# Altium schematic sheet Main.SchDoc
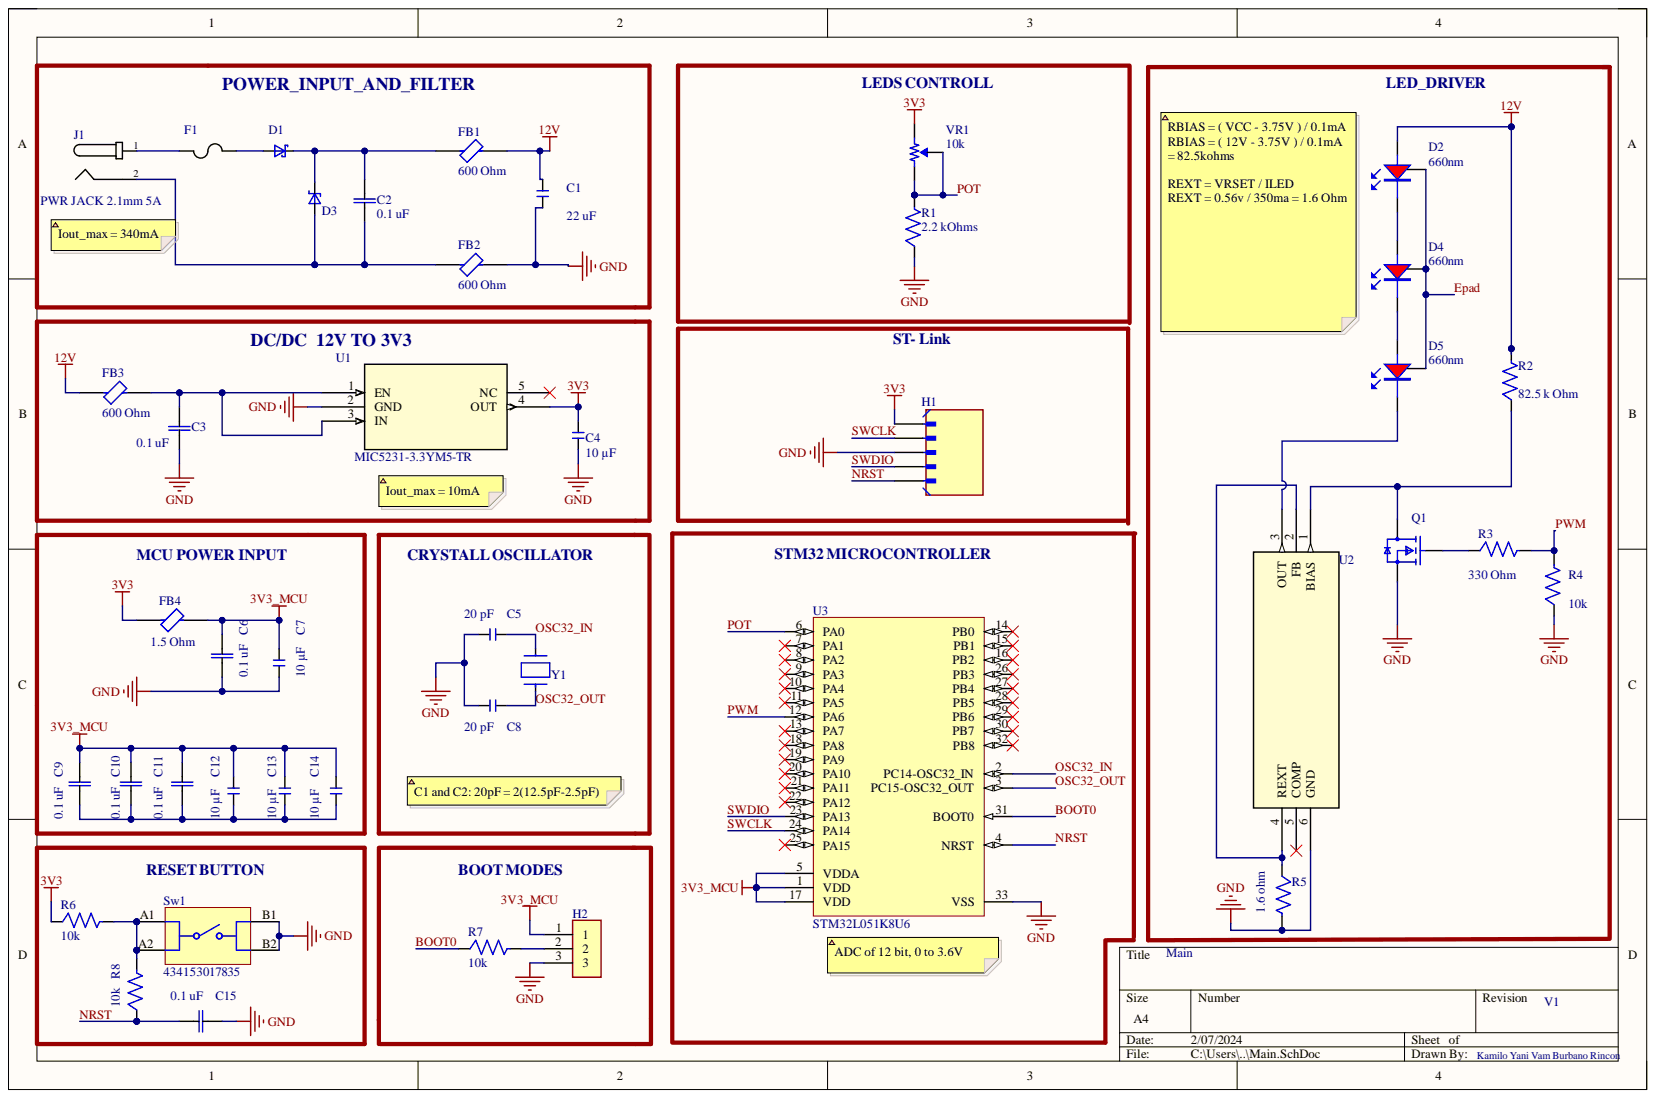
[diagram p0: Main.SchDoc]

## Parts
C1  value 22 uF  [0805]  (hidden comment: 22uF 10%)
C2  value 0.1 uF  [0603]  (hidden comment: 0.1uF  10%)
C3  value 0.1 uF  [0603]  (hidden comment: 0.1uF  10%)
C4  value 10 µF  [0805]  (hidden comment: 10 µF 20%)
C5  value 20 pF  [0603]  (hidden comment: 20pF 5%)
C6  value 0.1 uF  [0603]  (hidden comment: 0.1uF  10%)
C7  value 10 µF  [0805]  (hidden comment: 10 µF 20%)
C8  value 20 pF  [0603]  (hidden comment: 20pF 5%)
C9  value 0.1 uF  [0603]  (hidden comment: 0.1uF  10%)
C10  value 0.1 uF  [0603]  (hidden comment: 0.1uF  10%)
C11  value 0.1 uF  [0603]  (hidden comment: 0.1uF  10%)
C12  value 10 µF  [0805]  (hidden comment: 10 µF 20%)
C13  value 10 µF  [0805]  (hidden comment: 10 µF 20%)
C14  value 10 µF  [0805]  (hidden comment: 10 µF 20%)
C15  value 0.1 uF  [0603]  (hidden comment: 0.1uF  10%)
D1  RSX071VAM30TR  [TUMD2M]  (value not drawn)
D2  660nm  [LED_3535_EP]
D3  ESDA13P70-1U1M  [1610]  (value not drawn)
D4  660nm  [LED_3535_EP]
D5  660nm  [LED_3535_EP]
F1  MFU0603FF00630P100  [0603]  (value not drawn)
FB1  value 600 Ohm  [0805]  (hidden comment: BLM21AG601SN1D)
FB2  value 600 Ohm  [0805]  (hidden comment: BLM21AG601SN1D)
FB3  value 600 Ohm  [0805]  (hidden comment: BLM21AG601SN1D)
FB4  value 1.5 Ohm  [0805]  (hidden comment: HZ0603A252R-10)
H1  77311-462K05LF  [H_5x1_TH_2.54mm]  (value not drawn)
H2  TSM-103-01-L-SV-TR  [H_3x1_SMD_2.54mm]  (value not drawn)
J1  PWR JACK 2.1mm 5A  [CON_POWER_BARREL_2.1MM_2CONN]
Q1  2N7002-TP  [SOT_23_3]  (value not drawn)
R1  value 2.2 kOhms  [0603]  (hidden comment: 2.2 kOhms   1/10W)
R2  value 82.5 k Ohm  [0603]  (hidden comment: 82.5 kOhm 1/10W)
R3  value 330 Ohm  [0603]  (hidden comment: 330 Ohm 1/10W)
R4  value 10k  [0603]  (hidden comment: 10k 1/16W)
R5  value 1.6 ohm  [0603]  (hidden comment: 1.6 Ohm 1/10W)
R6  value 10k  [0603]  (hidden comment: 10k 1/16W)
R7  value 10k  [0603]  (hidden comment: 10k 1/16W)
R8  value 10k  [0603]  (hidden comment: 10k 1/16W)
Sw1  434153017835  [Sw_PUSH_2Pos_1.7mmx3.5mmx2.9mm]
U1  MIC5231-3.3YM5-TR  [SOT_23_5]
U2  AL5801W6-7  [SOT_23_6]  (value not drawn)
U3  STM32L051K8U6  [UFQFN_32_5x5mm]
VR1  value 10k  [Pot_3x1_5mm_TH]  (hidden comment: 10k 1/5W)
Y1  ECS-.327-12.5-34B-TR  [SMD_2_3.2mmx1.5mm]  (value not drawn)

## Nets
3V3: C4.2 FB4.1 H1.01 R6.1 U1.4(OUT) VR1.1
3V3_MCU: C6.2 C7.2 C9.2 C10.2 C11.2 C12.2 C13.2 C14.2 FB4.2 H2.1 U3.1(VDD) U3.5(VDDA) U3.17(VDD)
12V: C1.2 D2.2 FB1.2 FB3.1 R2.2
GND: C1.1 C3.1 C4.1 C5.1 C6.1 C7.1 C8.1 C15.1 FB2.2 H1.03 H2.3 Q1.2 R1.1 R4.1 R5.2 Sw1.B1 Sw1.B2 U1.2(GND) U2.6(GND) U3.33(VSS)
BOOT0: R7.1 U3.31(BOOT0)
Epad: D2.3 D4.3 D5.3
NRST: C15.2 H1.05 R8.1 U3.4(NRST)
OSC32_IN: C5.2 U3.2(PC14-OSC32_IN) Y1.2
OSC32_OUT: C8.2 U3.3(PC15-OSC32_OUT) Y1.1
POT: R1.2 U3.6(PA0) VR1.2 VR1.3
PWM: R3.2 R4.2 U3.12(PA6)
SWCLK: H1.02 U3.24(PA14)
SWDIO: H1.04 U3.23(PA13)
NetC2_1: C2.1 D3.2 FB2.1 J1.2
NetC2_2: C2.2 D1.1 D3.1 FB1.1
NetC3_2: C3.2 FB3.2 U1.1(EN) U1.3(IN)
NetC9_1: C9.1 C10.1 C11.1 C12.1 C13.1 C14.1
NetD1_2: D1.2 F1.2
NetD2_1: D2.1 D4.2
NetD4_1: D4.1 D5.2
NetD5_1: D5.1 U2.3(OUT)
NetF1_1: F1.1 J1.1
NetH2_2: H2.2 R7.2
NetQ1_1: Q1.1 R3.1
NetQ1_3: Q1.3 R2.1 U2.1(BIAS)
NetR5_1: R5.1 U2.2(FB) U2.4(REXT)
NetR6_2: R6.2 R8.2 Sw1.A1 Sw1.A2

Not connected: U1 5(NC); U2 5(COMP); U3 7(PA1) 8(PA2) 9(PA3) 10(PA4) 11(PA5) 13(PA7) 14(PB0) 15(PB1) 16(PB2) 18(PA8) 19(PA9) 20(PA10) 21(PA11) 22(PA12) 25(PA15) 26(PB3) 27(PB4) 28(PB5) 29(PB6) 30(PB7) 32(PB8)

## Library data of the parts
C1 = Cap_22uF_0805_16V: CAP CER 22UF 16V X5R 0805; Manufacturer: Samsung Electro-Mechanics; Manufacturer Part Number: CL21A226KOQNNNE; Component Kind: Standard; ComponentLink1Description: Digi-Key; ComponentLink1URL: https://www.digikey.com/en/products/detail/samsung-electro-mechanics/CL21A226KOQNNNE/5961010?s=N4IgTCBcDaIMIBkwEYCCYwDYDSB5AigHLECiIAugL5A; Last modified date: 20231204; Supplier 1: Digi-key; Supplier Part Number 1: 1276-6526-1-ND; Last modified by: K-Y.Burbano; Library Name: Final-test-case-HW-2023-2.IntLib
C2 C3 C6 C9-C11 C15 = Cap_0.1uF_0603_50V: CAP CER 0.1UF 50V X7R 0603; Manufacturer: Samsung Electro-Mechanics; Manufacturer Part Number: CL10B104KB8NNNL; Component Kind: Standard; ComponentLink1Description: Digi-Key; ComponentLink1URL: https://www.digikey.com/en/products/detail/samsung-electro-mechanics/CL10B104KB8NNNL/3894274?s=N4IgTCBcDaIMIBkCMAGAQqgLAaTQDgDkiEQBdAXyA; Last modified date: 20231204; Supplier 1: Digi-key; Supplier Part Number 1: 1276-CL10B104KB8NNNLCT-ND; Last modified by: K-Y.Burbano; Library Name: Final-test-case-HW-2023-2.IntLib
C4 C7 C12-C14 = Cap_10uf_0805_6.3V: CAP CER 10UF 6.3V X5R 0805; Manufacturer: Taiyo Yuden; Manufacturer Part Number: JMK212BJ106MG-T; Component Kind: Standard; ComponentLink1Description: Digi-Key; ComponentLink1URL: https://www.digikey.com/en/products/detail/taiyo-yuden/JMK212BJ106MG-T/1169928?s=N4IgTCBcDaIFIFkDSYCMYBCdUAYBsCA4gLQAqIAugL5A; Last modified date: 20231204; Supplier 1: Digi-key; Supplier Part Number 1: 587-1943-1-ND; Last modified by: K-Y.Burbano; Library Name: Final-test-case-HW-2023-2.IntLib
C5 C8 = Cap_20pF_0603_50V: CAP CER 20PF 50V C0G/NP0 0603; Manufacturer: Walsin Technology Corporation; Manufacturer Part Number: 0603N200J500CT; Component Kind: Standard; ComponentLink1Description: Digi-Key; ComponentLink1URL: https://www.digikey.com/en/products/detail/walsin-technology-corporation/0603N200J500CT/9354995; Last modified date: 20240105; Supplier 1: Digi-key; Supplier Part Number 1: 1292-1498-1-ND; Last modified by: K-Y.Burbano; Library Name: Final-test-case-HW-2023-2.IntLib
D1 = D_Schottky_30V_700mA_TUMD2M: DIODE SCHOTTKY 30V 700MA TUMD2M; Manufacturer: Rohm Semiconductor; Component Kind: Standard; ComponentLink1Description: Digi-Key; ComponentLink1URL: https://www.digikey.com/en/products/detail/rohm-semiconductor/RSX071VAM30TR/8037954; Last modified date: 20231204; Manufacturer Part Number: RSX071VAM30TR; Supplier 1: Digi-key; Supplier Part Number 1: RSX071VAM30CT-ND; Last modified by: K-Y.Burbano; Library Name: Final-test-case-HW-2023-2.IntLib
D2 D4 D5 = LED_Red_660nm: XPE HE PHOTO RED LIGHT EMITTING; Manufacturer: Cree Inc.; Manufacturer Part Number: XPEEPR-L1-0000-00901; Component Kind: Standard; ComponentLink1Description: Digi-Key; ComponentLink1URL: https://www.digikey.com/product-detail/en/cree-inc/XPEEPR-L1-0000-00901/XPEEPR-L1-0000-00901CT-ND/9954362; Last modified date: 20210602; Supplier 1: Digi-Key; Supplier Part Number 1: XPEEPR-L1-0000-00901CT-ND; Last modified by: J-E.Pachon; Library Name: Final-test-case-HW-2023-2.IntLib
D3 = TVS_12V_1CH_1610: TVS DIODE 12VWM 20VC 1610; Manufacturer: STMicroelectronics; Component Kind: Standard; ComponentLink1Description: Digi-Key; ComponentLink1URL: https://www.digikey.com/en/products/detail/stmicroelectronics/ESDA13P70-1U1M/5805503?s=N4IgTCBcDaIKIGUAiBBAjAZgAoHYAMAtGgKpoCyIAugL5A; Last modified date: 20231204; Manufacturer Part Number: ESDA13P70-1U1M; Supplier 1: Digi-key; Supplier Part Number 1: 497-16271-6-ND; Last modified by: K-Y.Burbano; Library Name: Final-test-case-HW-2023-2.IntLib
F1 = F_630mA_32V_CR_Excluded: FUSE BOARD MNT 630MA 32VDC 0603; Manufacturer: Vishay Beyschlag/Draloric/BC Components; Component Kind: Standard; ComponentLink1Description: Digi-Key; ComponentLink1URL: https://www.digikey.com/en/products/detail/vishay-beyschlag-draloric-bc-components/MFU0603FF00630P100/1206472?s=N4IgTCBcDaILIDECqAGAbCgzAhL2ZQAUBGPEAXQF8g; Last modified date: 20231204; Manufacturer Part Number: MFU0603FF00630P100; Supplier 1: Digi-key; Supplier Part Number 1: MFU0603.63CT-ND; Last modified by: K-Y.Burbano; Library Name: Final-test-case-HW-2023-2.IntLib
FB1-FB3 = FB_600Ohm_0805_600mA: FERRITE BEAD 600 OHM 0805 1LN; Manufacturer: Murata Electronics; Component Kind: Standard; ComponentLink1Description: Digi-Key; ComponentLink1URL: https://www.digikey.com/en/products/detail/murata-electronics/BLM21AG601SN1D/584251?s=N4IgTCBcDaIEIBkCyYCMBBA4gNgAyoGUA5VAERAF0BfIA; Last modified date: 20231204; Manufacturer Part Number: BLM21AG601SN1D; Supplier 1: Digi-key; Supplier Part Number 1: 490-1040-1-ND; Last modified by: K-Y.Burbano; Library Name: Final-test-case-HW-2023-2.IntLib
FB4 = FB_1.5Ohm_0603_50mA: FERRITE BEAD 2.5 KOHM 0603 1LN; Manufacturer: Laird-Signal Integrity Products; Component Kind: Standard; ComponentLink1Description: Digi-Key; ComponentLink1URL: https://www.digikey.com/en/products/detail/laird-signal-integrity-products/HZ0603A252R-10/1236417?s=N4IgjCBcpgnATAZiqAxlAZgQwDYGcBTAGhAHsoBtEJADjAAYIBdEgBwBcoQBldgJwCWAOwDmIAL4k4NWChDpI2fMTKUQ9EE0nUwANlnR5mXIRLlIVZm06QQASSHsCIgnwkkAtPDkL%2BAVxVzKgBWTW0PUMMFJVNVC2owzwAWH2NlMzVYPXpdMO1veIAxAFEAJVK7ABVigAIAIWKAQQARMKA; Last modified date: 20240105; Manufacturer Part Number: HZ0603A252R-10; Supplier 1: Digi-key; Supplier Part Number 1: 240-2561-1-ND; Last modified by: K-Y.Burbano; Library Name: Final-test-case-HW-2023-2.IntLib
H1 = H_5_1_TH_2.54mm: CONN HEADER VERT 5POS 2.54MM; Manufacturer: Amphenol ICC (FCI); Component Kind: Standard; ComponentLink1Description: Digi-Key; ComponentLink1URL: https://www.digikey.com/en/products/detail/amphenol-cs-fci/77311-462K05LF/2665590; Last modified date: 20231213; Manufacturer Part Number: 77311-462K05LF; Supplier 1: Digi-key; Supplier Part Number 1: 609-4535-1-ND; Last modified by: K-Y.Burbano; Library Name: Final-test-case-HW-2023-2.IntLib
H2 = H_3x1_SMD_2.54mm_Header: CONN HEADER SMD 3POS 2.54MM; Manufacturer: Samtec Inc.; Component Kind: Standard; ComponentLink1Description: Digi-Key; ComponentLink1URL: https://www.digikey.com/en/products/detail/samtec-inc/TSM-103-01-L-SV-TR/6691760; Last modified date: 20231216; Manufacturer Part Number: TSM-103-01-L-SV-TR; Supplier 1: Digi-key; Supplier Part Number 1: SAM14791CT-ND; Last modified by: K-Y.Burbano; Library Name: Final-test-case-HW-2023-2.IntLib
J1 = Con_Power_Jack_2.1mm_2pins_1: CONN PWR JACK 2X5.5MM SOLDER; Manufacturer: CUI Devices; Manufacturer Part Number: PJ-037AH; Component Kind: Standard; ComponentLink1Description: Digi-Key; ComponentLink1URL: https://www.digikey.com/product-detail/en/cui-devices/PJ-037AH/CP-037AH-ND/1644547; Last modified date: 20210723; Supplier 1: Digi-Key; Supplier Part Number 1: CP-037AH-ND; Last modified by: C-D Viveros; Library Name: Final-test-case-HW-2023-2.IntLib
Q1 = Q_NFET_60V_0.34A_SOT_23_3: MOSFET N-CH 60V 115MA SOT23; Manufacturer: Micro Commercial Co; Component Kind: Standard; ComponentLink1Description: Digi-Key; ComponentLink1URL: https://www.digikey.com.mx/en/products/detail/micro-commercial-co/2N7002-TP/1959296; Last modified date: 20231218; Manufacturer Part Number: 2N7002-TP; Supplier 1: Digi-key; Supplier Part Number 1: 2N7002-TPMSCT-ND; Last modified by: K-Y.Burbano; Library Name: Final-test-case-HW-2023-2.IntLib
R1 = Res_2.2kOhm_0603_1/10W: RES SMD 2.2K OHM 0.1% 1/10W 0603; Manufacturer: YAGEO; Manufacturer Part Number: RT0603BRD072K2L; Component Kind: Standard; ComponentLink1Description: Digi-Key; ComponentLink1URL: https://www.digikey.com/en/products/detail/yageo/RT0603BRD072K2L/1072430; Last modified date: 20240105; Supplier 1: Digi-key; Supplier Part Number 1: YAG1616CT-ND; Last modified by: K-Y.Burbano; Library Name: Final-test-case-HW-2023-2.IntLib
R2 = Res_82.5kOhm_0603_1/10W: RES 82.5K OHM 1% 1/10W 0603; Manufacturer: Stackpole Electronics Inc; Manufacturer Part Number: RMCF0603FT82K5; Component Kind: Standard; ComponentLink1Description: Digi-Key; ComponentLink1URL: https://www.digikey.com/en/products/detail/stackpole-electronics-inc/RMCF0603FT82K5/1760958; Last modified date: 20240105; Supplier 1: Digi-Key; Supplier Part Number 1: RMCF0603FT82K5CT-ND; Last modified by: K-Y.Burbano; Library Name: Final-test-case-HW-2023-2.IntLib
R3 = Res_330Ohm_0603_1/10W: RES 330 OHM 1% 1/10W 0603; Manufacturer: YAGEO; Manufacturer Part Number: RC0603FR-13330RL; Component Kind: Standard; ComponentLink1Description: Digi-Key; ComponentLink1URL: https://www.digikey.com.mx/en/products/detail/yageo/RC0603FR-13330RL/13694183; Last modified date: 20231218; Supplier 1: Digi-key; Supplier Part Number 1: 13-RC0603FR-13330RLCT-ND; Last modified by: K-Y.Burbano; Library Name: Final-test-case-HW-2023-2.IntLib
R4 R6-R8 = Res_10k_0603_1/16W: RES SMD 10K OHM 0.1% 1/16W 0603; Manufacturer: TE Connectivity Passive Product; Manufacturer Part Number: CPF-A-0603B10KE1; Component Kind: Standard; ComponentLink1Description: Digi-Key; ComponentLink1URL: https://www.digikey.com/en/products/detail/te-connectivity-passive-product/CPF-A-0603B10KE1/5586834; Last modified date: 20231124; Supplier 1: Digi-key; Supplier Part Number 1: 1712-CPF-A-0603B10KE1DKR-ND; Last modified by: K-Y.Burbano; Library Name: Final-test-case-HW-2023-2.IntLib
R5 = Res_1.6Ohm_0603_1/10W: RES 1.6 OHM 1% 1/10W 0603; Manufacturer: YAGEO; Manufacturer Part Number: RC0603FR-071R6L; Component Kind: Standard; ComponentLink1Description: Digi-Key; ComponentLink1URL: https://www.digikey.com/en/products/detail/yageo/RC0603FR-071R6L/5918296; Last modified date: 20240105; Supplier 1: Digi-Key; Supplier Part Number 1: 13-RC0603FR-071R6LCT-ND; Last modified by: K-Y.Burbano; Library Name: Final-test-case-HW-2023-2.IntLib
Sw1 = Sw_PUSH_1.7mmx3.5mmx2.90mm: SWITCH TACTILE SPST-NO 0.05A 12V; Manufacturer: Würth Elektronik; Component Kind: Standard; ComponentLink1Description: Digi-Key; ComponentLink1URL: https://www.digikey.com/en/products/detail/w%C3%BCrth-elektronik/434153017835/5956410; Last modified date: 20231213; Manufacturer Part Number: 434153017835; Supplier 1: Digi-key; Supplier Part Number 1: 732-10143-1-ND; Last modified by: K-Y.Burbano; Library Name: Final-test-case-HW-2023-2.IntLib
U1 = DCDC_3.3V_10mA_SOT23-5: IC REG LINEAR 3.3V 10MA SOT23-5; Manufacturer: Microchip Technology; Component Kind: Standard; ComponentLink1Description: Digi-Key; ComponentLink1URL: https://www.digikey.com/en/products/detail/microchip-technology/MIC5231-3-3YM5-TR/1030596; Last modified date: 20240105; Manufacturer Part Number: MIC5231-3.3YM5-TR; Supplier 1: Digi-key; Supplier Part Number 1: 576-2772-1-ND; Last modified by: K-Y.Burbano; Library Name: Final-test-case-HW-2023-2.IntLib
U2 = IC_LED_DRVR: IC LED DRVR LIN PWM 350MA SOT26; Manufacturer: Diodes Incorporated; Component Kind: Standard; ComponentLink1Description: Digi-Key; ComponentLink1URL: https://www.digikey.com/en/products/detail/diodes-incorporated/AL5801W6-7/3481117; Last modified date: 20240105; Manufacturer Part Number: AL5801W6-7; Supplier 1: Digi-key; Supplier Part Number 1: AL5801W6-7DICT-ND; Last modified by: K-Y.Burbano; Library Name: Final-test-case-HW-2023-2.IntLib
U3 = IC_MCU_STM32L051K8U6: IC MCU 32BIT 64KB FLASH 32UFQFPN; Manufacturer: STMicroelectronics; Component Kind: Standard; ComponentLink1Description: Datasheet; ComponentLink1URL: http://www.st.com/content/ccc/resource/technical/document/datasheet/9a/75/bd/16/0f/fd/49/19/DM00108219.pdf/files/DM00108219.pdf/jcr:content/translations/en.DM00108219.pdf; ComponentLink2Description: Digi-Key; ComponentLink2URL: https://www.digikey.com/en/products/detail/stmicroelectronics/STM32L051K8U6/5051352?s=N4IgTCBcDaICwE4DsBaAjExAGFA5AIiALoC%2BQA; Last modified date: 20210511; Manufacturer Part Number: STM32L051K8U6; Supplier 1: Digi-Key; Supplier Part Number 1: 497-17490-ND; Last modified by: J-E.Pachon; Library Name: Final-test-case-HW-2023-2.IntLib
VR1 = Pot_10k_1/5W_TH: POT 10K OHM 1/5W PLASTIC LINEAR; Manufacturer: TT Electronics/BI; Manufacturer Part Number: P160KN-0QC15B10K; Component Kind: Standard; ComponentLink1Description: Digi-key; ComponentLink1URL: https://www.digikey.com/products/en?keywords=P160KN-0QC15B10K; Last modified date: 20210513; Supplier 1: Digi-Key; Supplier Part Number 1: 987-1301-ND; Last modified by: J-E.Pachon; Library Name: Final-test-case-HW-2023-2.IntLib
Y1 = Xtal_32.7680KHz_3.2mmx1.5mm: CRYSTAL 32.7680KHZ 12.5PF SMD; Manufacturer: ECS Inc.; Value: NM; Component Kind: Standard; ComponentLink1Description: Digi-Key; ComponentLink1URL: https://www.digikey.com/en/products/detail/ecs-inc/ECS-327-12-5-34B-TR/1693557; Last modified date: 20231213; Manufacturer Part Number: ECS-.327-12.5-34B-TR; Supplier 1: Digi-key; Supplier Part Number 1: XC1617CT-ND; Last modified by: K-Y.Burbano; Library Name: Final-test-case-HW-2023-2.IntLib
